# Altium schematic sheet PCIe PowerSupply.SchDoc
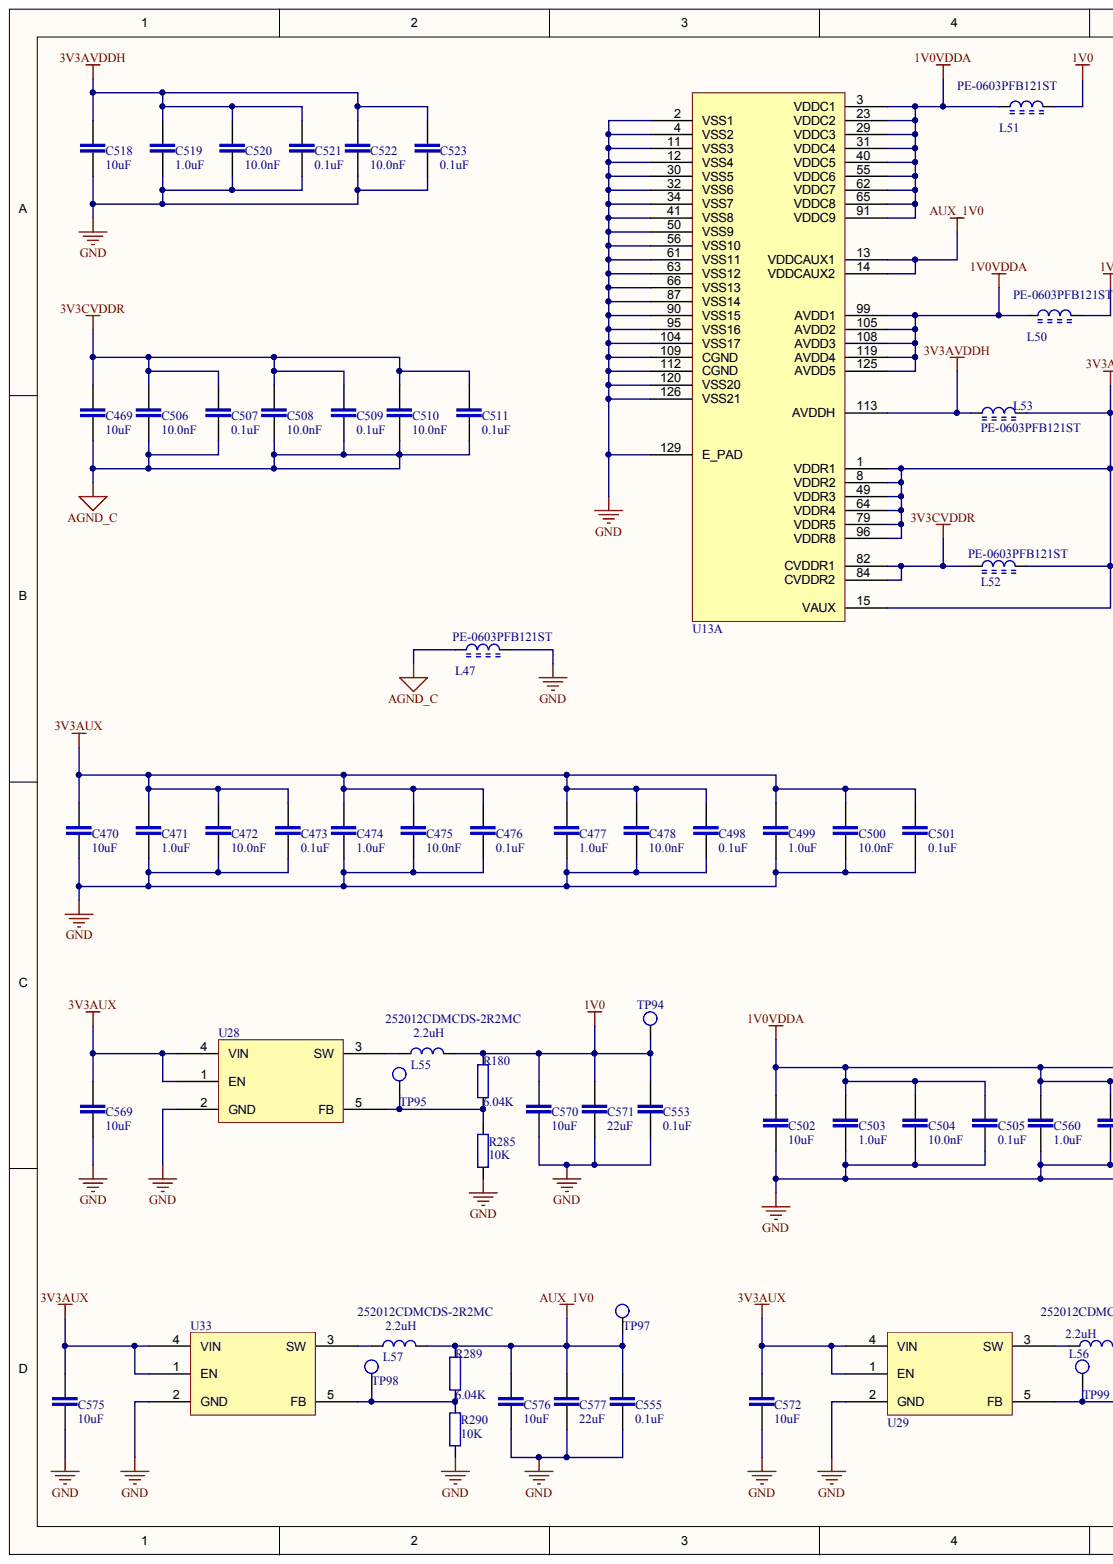
[diagram p0: PCIe PowerSupply.SchDoc, left]
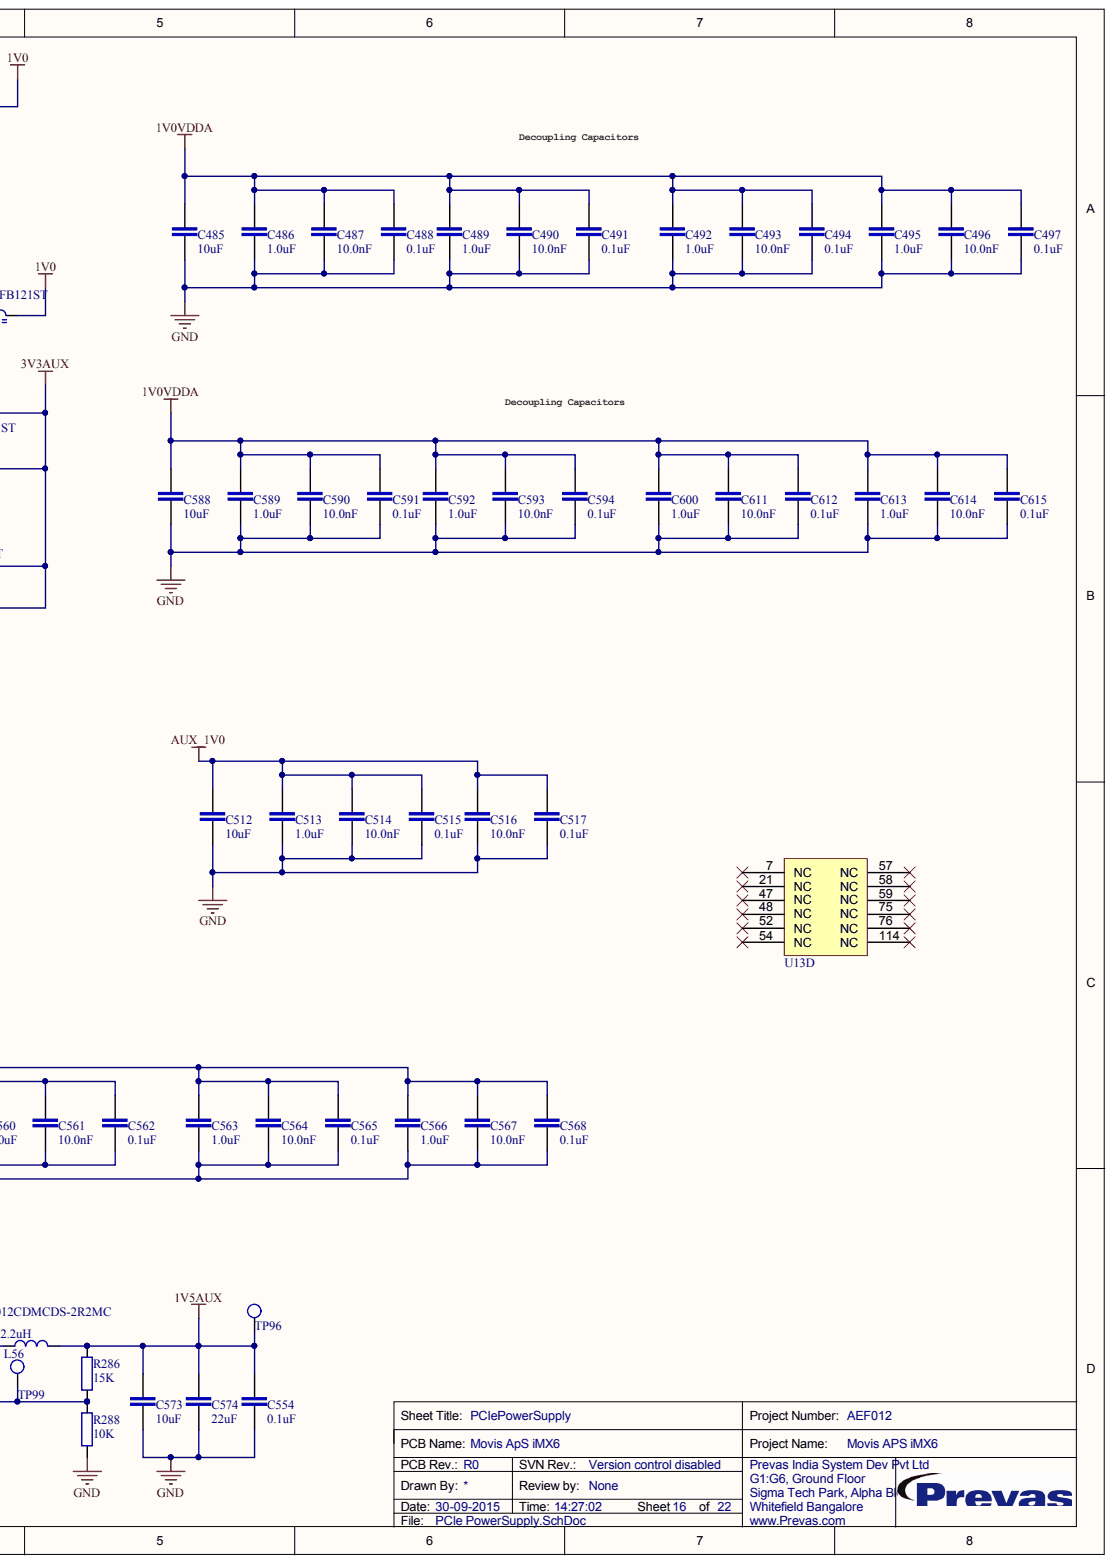
[diagram p1: PCIe PowerSupply.SchDoc, right]

## Parts
C469  10uF  [CAPC1608X95N]
C470  10uF  [CAPC1608X95N]
C471  1.0uF  [CAPC1608X90N]
C472  10.0nF  [CAPC1005X55N]
C473  0.1uF  [CAPC1005X55N]
C474  1.0uF  [CAPC1608X90N]
C475  10.0nF  [CAPC1005X55N]
C476  0.1uF  [CAPC1005X55N]
C477  1.0uF  [CAPC1608X90N]
C478  10.0nF  [CAPC1005X55N]
C485  10uF  [CAPC1608X95N]
C486  1.0uF  [CAPC1608X90N]
C487  10.0nF  [CAPC1005X55N]
C488  0.1uF  [CAPC1005X55N]
C489  1.0uF  [CAPC1608X90N]
C490  10.0nF  [CAPC1005X55N]
C491  0.1uF  [CAPC1005X55N]
C492  1.0uF  [CAPC1608X90N]
C493  10.0nF  [CAPC1005X55N]
C494  0.1uF  [CAPC1005X55N]
C495  1.0uF  [CAPC1608X90N]
C496  10.0nF  [CAPC1005X55N]
C497  0.1uF  [CAPC1005X55N]
C498  0.1uF  [CAPC1005X55N]
C499  1.0uF  [CAPC1608X90N]
C500  10.0nF  [CAPC1005X55N]
C501  0.1uF  [CAPC1005X55N]
C502  10uF  [CAPC1608X95N]
C503  1.0uF  [CAPC1608X90N]
C504  10.0nF  [CAPC1005X55N]
C505  0.1uF  [CAPC1005X55N]
C506  10.0nF  [CAPC1005X55N]
C507  0.1uF  [CAPC1005X55N]
C508  10.0nF  [CAPC1005X55N]
C509  0.1uF  [CAPC1005X55N]
C510  10.0nF  [CAPC1005X55N]
C511  0.1uF  [CAPC1005X55N]
C512  10uF  [CAPC1608X95N]
C513  1.0uF  [CAPC1608X90N]
C514  10.0nF  [CAPC1005X55N]
C515  0.1uF  [CAPC1005X55N]
C516  10.0nF  [CAPC1005X55N]
C517  0.1uF  [CAPC1005X55N]
C518  10uF  [CAPC1608X95N]
C519  1.0uF  [CAPC1608X90N]
C520  10.0nF  [CAPC1005X55N]
C521  0.1uF  [CAPC1005X55N]
C522  10.0nF  [CAPC1005X55N]
C523  0.1uF  [CAPC1005X55N]
C553  0.1uF  [CAPC1005X55N]
C554  0.1uF  [CAPC1005X55N]
C555  0.1uF  [CAPC1005X55N]
C560  1.0uF  [CAPC1608X90N]
C561  10.0nF  [CAPC1005X55N]
C562  0.1uF  [CAPC1005X55N]
C563  1.0uF  [CAPC1608X90N]
C564  10.0nF  [CAPC1005X55N]
C565  0.1uF  [CAPC1005X55N]
C566  1.0uF  [CAPC1608X90N]
C567  10.0nF  [CAPC1005X55N]
C568  0.1uF  [CAPC1005X55N]
C569  10uF  [CAPC1608X95N]
C570  10uF  [CAPC1608X95N]
C571  22uF  [CAPC3216X180N]
C572  10uF  [CAPC1608X95N]
C573  10uF  [CAPC1608X95N]
C574  22uF  [CAPC3216X180N]
C575  10uF  [CAPC1608X95N]
C576  10uF  [CAPC1608X95N]
C577  22uF  [CAPC3216X180N]
C588  10uF  [CAPC1608X95N]
C589  1.0uF  [CAPC1608X90N]
C590  10.0nF  [CAPC1005X55N]
C591  0.1uF  [CAPC1005X55N]
C592  1.0uF  [CAPC1608X90N]
C593  10.0nF  [CAPC1005X55N]
C594  0.1uF  [CAPC1005X55N]
C600  1.0uF  [CAPC1608X90N]
C611  10.0nF  [CAPC1005X55N]
C612  0.1uF  [CAPC1005X55N]
C613  1.0uF  [CAPC1608X90N]
C614  10.0nF  [CAPC1005X55N]
C615  0.1uF  [CAPC1005X55N]
L47  PE-0603PFB121ST  [INDC1608X100N]
L50  PE-0603PFB121ST  [INDC1608X100N]
L51  PE-0603PFB121ST  [INDC1608X100N]
L52  PE-0603PFB121ST  [INDC1608X100N]
L53  PE-0603PFB121ST  [INDC1608X100N]
L55  2.2uH  [INDC2621X12N]
L56  2.2uH  [INDC2621X12N]
L57  2.2uH  [INDC2621X12N]
R180  6.04K  [RESC1608X55N]
R285  10K  [RESC1608X55N]
R286  15K  [RESC1608X55N]
R288  10K  [RESC1608X55N]
R289  6.04K  [RESC1608X55N]
R290  10K  [RESC1608X55N]
TP94  Testpoint  [TP_SMD]  (value not drawn)  (no BOM)
TP95  Testpoint  [TP_SMD]  (value not drawn)  (no BOM)
TP96  Testpoint  [TP_SMD]  (value not drawn)  (no BOM)
TP97  Testpoint  [TP_SMD]  (value not drawn)  (no BOM)
TP98  Testpoint  [TP_SMD]  (value not drawn)  (no BOM)
TP99  Testpoint  [TP_SMD]  (value not drawn)  (no BOM)
U13  PI7C9X2G304_SCH  [LQFP40P1600X1600X160_HS-129N]  (value not drawn)  (parts A,D)
U28  AP3418KTR-G1  [SOT95P282X145-5N]  (value not drawn)
U29  AP3418KTR-G1  [SOT95P282X145-5N]  (value not drawn)
U33  AP3418KTR-G1  [SOT95P282X145-5N]  (value not drawn)

## Nets
1V0: C553.1 C570.2 C571.2 L50.2 L51.2 L55.2 R180.2 TP94.1
1V0VDDA: C485.2 C486.1 C487.2 C488.2 C489.1 C490.2 C491.2 C492.1 C493.2 C494.2 C495.1 C496.2 C497.2 C502.2 C503.1 C504.2 C505.2 C560.1 C561.2 C562.2 C563.1 C564.2 C565.2 C566.1 C567.2 C568.2 C588.2 C589.1 C590.2 C591.2 C592.1 C593.2 C594.2 C600.1 C611.2 C612.2 C613.1 C614.2 C615.2 L50.1 L51.1 U13.3(VDDC1) U13.23(VDDC2) U13.29(VDDC3) U13.31(VDDC4) U13.40(VDDC5) U13.55(VDDC6) U13.62(VDDC7) U13.65(VDDC8) U13.91(VDDC9) U13.99(AVDD1) U13.105(AVDD2) U13.108(AVDD3) U13.119(AVDD4) U13.125(AVDD5)
1V5AUX: C554.1 C573.2 C574.2 L56.2 R286.2 TP96.1
3V3AUX: C470.2 C471.1 C472.2 C473.2 C474.1 C475.2 C476.2 C477.1 C478.2 C498.2 C499.1 C500.2 C501.2 C569.2 C572.2 C575.2 L52.2 L53.1 U13.1(VDDR1) U13.8(VDDR2) U13.15(VAUX) U13.49(VDDR3) U13.64(VDDR4) U13.79(VDDR5) U13.96(VDDR8) U28.1(EN) U28.4(VIN) U29.1(EN) U29.4(VIN) U33.1(EN) U33.4(VIN)
3V3AVDDH: C518.2 C519.1 C520.2 C521.2 C522.2 C523.2 L53.2 U13.113(AVDDH)
3V3CVDDR: C469.2 C506.2 C507.2 C508.2 C509.2 C510.2 C511.2 L52.1 U13.82(CVDDR1) U13.84(CVDDR2)
AGND_C: C469.1 C506.1 C507.1 C508.1 C509.1 C510.1 C511.1 L47.1
AUX_1V0: C512.2 C513.1 C514.2 C515.2 C516.2 C517.2 C555.1 C576.2 C577.2 L57.2 R289.2 TP97.1 U13.13(VDDCAUX1) U13.14(VDDCAUX2)
GND: C470.1 C471.2 C472.1 C473.1 C474.2 C475.1 C476.1 C477.2 C478.1 C485.1 C486.2 C487.1 C488.1 C489.2 C490.1 C491.1 C492.2 C493.1 C494.1 C495.2 C496.1 C497.1 C498.1 C499.2 C500.1 C501.1 C502.1 C503.2 C504.1 C505.1 C512.1 C513.2 C514.1 C515.1 C516.1 C517.1 C518.1 C519.2 C520.1 C521.1 C522.1 C523.1 C553.2 C554.2 C555.2 C560.2 C561.1 C562.1 C563.2 C564.1 C565.1 C566.2 C567.1 C568.1 C569.1 C570.1 C571.1 C572.1 C573.1 C574.1 C575.1 C576.1 C577.1 C588.1 C589.2 C590.1 C591.1 C592.2 C593.1 C594.1 C600.2 C611.1 C612.1 C613.2 C614.1 C615.1 L47.2 R285.1 R288.1 R290.1 U13.2(VSS1) U13.4(VSS2) U13.11(VSS3) U13.12(VSS4) U13.30(VSS5) U13.32(VSS6) U13.34(VSS7) U13.41(VSS8) U13.50(VSS9) U13.56(VSS10) U13.61(VSS11) U13.63(VSS12) U13.66(VSS13) U13.87(VSS14) U13.90(VSS15) U13.95(VSS16) U13.104(VSS17) U13.109(CGND) U13.112(CGND) U13.120(VSS20) U13.126(VSS21) U13.129(E_PAD) U28.2(GND) U29.2(GND) U33.2(GND)
NetL55_1: L55.1 U28.3(SW)
NetL56_1: L56.1 U29.3(SW)
NetL57_1: L57.1 U33.3(SW)
NetR180_1: R180.1 R285.2 TP95.1 U28.5(FB)
NetR286_1: R286.1 R288.2 TP99.1 U29.5(FB)
NetR289_1: R289.1 R290.2 TP98.1 U33.5(FB)

Not connected: U13 7(NC) 21(NC) 47(NC) 48(NC) 52(NC) 54(NC) 57(NC) 58(NC) 59(NC) 75(NC) 76(NC) 114(NC)

## Library data of the parts
C469 C470 C485 C502 C512 C518 C569 C570 C572 C573 C575 C576 C588 = INNISI-78: CAP CER 10UF 16V 10% X5R 0603; ref_part_type: /capacitor/non_polarized/ceramic/; source_manufacturer_1: Murata; source_manufacturer_1_pn: GRM188R61C106KAALD; cae_altium_pcb_status: Prototype; Database Table Name: altium_default; db_rev: 7574; elec_temp_range: -55 to +85C; phy_height: 0.95mm; phy_mounting: SMD; phy_packaging_type: 0603; ref_datasheet: INNISI-75; ref_keyword: Ceramic capacitor X5R 0603; cae_altium_sch_status: Prototype; Component Kind: Standard; ComponentLink1Description: Datasheet; ComponentLink1URL: https://cop201.prevas.dk/viewvc/datasheet/INNISI-75.pdf?root=hw_components&view=co; db_created: 2015-08-03 05:08:42.0; db_last_updated: 03-08-2015 05:08:44; db_local_id: INNISI-78; db_status: Prototype; elec_tolerance: 10%; elec_value: 10uF; elec_voltage_rating: 16V; phy_material: X5R; Library Name: altium_mysql.dblib
C471 C474 C477 C486 C489 C492 C495 C499 C503 C513 C519 C560 C563 C566 C589 C592 C600 C613 = INNISI-79: CAP CER 1UF 16V 10% X7R 0603; ref_part_type: /capacitor/non_polarized/ceramic/; source_manufacturer_1: Murata; source_manufacturer_1_pn: GRM188R71C105KE15D; cae_altium_pcb_status: Prototype; Database Table Name: altium_default; db_rev: 7576; elec_temp_range: -55 to +125; phy_height: 0.90mm; phy_mounting: SMD; phy_packaging_type: 0603 (1608 Metric); ref_datasheet: INNISI-33; ref_keyword: Ceramic Capacitor 0603; cae_altium_sch_status: Prototype; Component Kind: Standard; ComponentLink1Description: Datasheet; ComponentLink1URL: https://cop201.prevas.dk/viewvc/datasheet/INNISI-33.pdf?root=hw_components&view=co; db_created: 2015-08-03 05:39:06.0; db_last_updated: 03-08-2015 05:39:08; db_local_id: INNISI-79; db_status: Prototype; elec_tolerance: 10%; elec_value: 1.0uF; elec_voltage_rating: 16V; phy_material: X7R; Library Name: altium_mysql.dblib
C472 C475 C478 C487 C490 C493 C496 C500 C504 C506 C508 C510 C514 C516 C520 C522 C561 C564 C567 C590 C593 C611 C614 = INNISI-82: CAP CER 10nF 50V 10% X7R 0402; ref_part_type: /capacitor/non_polarized/ceramic/; source_manufacturer_1: Yageo; source_manufacturer_1_pn: CC0402KRX7R9BB103; cae_altium_pcb_status: Prototype; Database Table Name: altium_default; db_rev: 7579; elec_temp_range: -55 to +125C; phy_height: 0.55mm; phy_mounting: SMD; phy_packaging_type: 0402; ref_datasheet: INNISI-72; ref_keyword: Ceramic capacitor; cae_altium_sch_status: Prototype; Component Kind: Standard; ComponentLink1Description: Datasheet; ComponentLink1URL: https://cop201.prevas.dk/viewvc/datasheet/INNISI-72.pdf?root=hw_components&view=co; db_created: 2015-08-03 05:52:48.0; db_last_updated: 03-08-2015 05:52:50; db_local_id: INNISI-82; db_status: Prototype; elec_tolerance: 10%; elec_value: 10.0nF; elec_voltage_rating: 50V; phy_material: X7R; Library Name: altium_mysql.dblib
C473 C476 C488 C491 C494 C497 C498 C501 C505 C507 C509 C511 C515 C517 C521 C523 C553-C555 C562 C565 C568 C591 C594 C612 C615 = INNISI-73: CAP CER 0.1UF 50V 10% X5R 0402; ref_part_type: /capacitor/non_polarized/ceramic/; source_manufacturer_1: TDK Corporation; source_manufacturer_1_pn: C1005X5R1H104K050BB; cae_altium_pcb_status: Prototype; Database Table Name: altium_default; db_rev: 7569; elec_temp_range: -55 to +85 C; phy_height: 0.55mm; phy_mounting: SMD; phy_packaging_type: 0402; ref_datasheet: INNISI-71; cae_altium_sch_status: Prototype; Component Kind: Standard; ComponentLink1Description: Datasheet; ComponentLink1URL: https://cop201.prevas.dk/viewvc/datasheet/INNISI-71.pdf?root=hw_components&view=co; db_created: 2015-08-03 03:34:59.0; db_last_updated: 03-08-2015 03:35:01; db_local_id: INNISI-73; db_status: Prototype; elec_tolerance: ±10%; elec_value: 0.1uF; elec_voltage_rating: 50V; phy_material: X5R; ref_keyword: CAP CER 0.1UF 50V 10% X5R 0402; Library Name: altium_mysql.dblib
C571 C574 C577 = INNISI-77: CAP CER 22UF 25V 10% X5R 1206; ref_part_type: /capacitor/non_polarized/ceramic/; source_manufacturer_1: Murata Electronics; source_manufacturer_1_pn: GRM31CR61E226KE15L; cae_altium_pcb_status: Prototype; Database Table Name: altium_default; db_rev: 7573; elec_temp_range: -55 to +85 C; phy_height: 1.8mm; phy_mounting: SMD; phy_packaging_type: 1206; ref_datasheet: INNISI-74; ref_keyword: Multilayer chip capacitor; cae_altium_sch_status: Prototype; Component Kind: Standard; ComponentLink1Description: Datasheet; ComponentLink1URL: https://cop201.prevas.dk/viewvc/datasheet/INNISI-74.pdf?root=hw_components&view=co; db_created: 2015-08-03 04:56:21.0; db_last_updated: 03-08-2015 04:56:22; db_local_id: INNISI-77; db_status: Prototype; elec_tolerance: 10%; elec_value: 22uF; elec_voltage_rating: 25V; phy_material: X5R; Library Name: altium_mysql.dblib
L47 L50-L53 = INNISI-23: EMI Filter Beads, Chips & Arrays 0603 120Ohms SMT FERRITE CHIP BEAD; ref_part_type: /inductor/; source_manufacturer_1: Pulse; cae_altium_pcb_status: Prototype; Database Table Name: altium_default; db_rev: 7356; elec_frequency_rating: 100MHz; elec_tolerance: ±25%; phy_mounting: SMD; phy_packaging_type: 0603; ref_keyword: EMI FERRITE CHIP BEAD; cae_altium_sch_status: Prototype; Component Kind: Standard; ComponentLink1Description: Datasheet; ComponentLink1URL: https://cop201.prevas.dk/viewvc/datasheet/INNISI-23.pdf?root=hw_components&view=co; db_created: 2015-06-02 08:57:45.0; db_last_updated: 02-06-2015 09:16:16; db_local_id: INNISI-23; db_status: Prototype; elec_value: PE-0603PFB121ST; ref_datasheet: INNISI-23; source_manufacturer_1_pn: PE-0603PFB121ST; Library Name: altium_mysql.dblib
L55-L57 = INNISI-24: Fixed Inductors SMD Power Inductor 2.2 uH 20% TOL; ref_part_type: /inductor/power/; source_manufacturer_1: Sumida; source_manufacturer_1_pn: 252012CDMCDS-2R2MC; cae_altium_pcb_status: Prototype; Database Table Name: altium_default; db_rev: 7357; elec_current_rating: 2.3A; elec_frequency_rating: 1MHz; elec_temp_range: - 40 C to + 105 C; phy_height: 2mm; phy_packaging_type: 1008 (2520 metric); ref_keyword: Power Inductors; cae_altium_sch_status: Prototype; Component Kind: Standard; ComponentLink1Description: Datasheet; ComponentLink1URL: https://cop201.prevas.dk/viewvc/datasheet/INNISI-24.pdf?root=hw_components&view=co; db_created: 2015-06-02 09:11:00.0; db_last_updated: 02-06-2015 09:17:06; db_local_id: INNISI-24; db_status: Prototype; elec_value: 2.2uH; phy_mounting: SMD; ref_datasheet: INNISI-24; Library Name: altium_mysql.dblib
R180 R289 = INVIHA-16: RES SMD 6.04K OHM 1% 1/10W 0603; ref_part_type: /resistor/fixed/; source_manufacturer_1: Yageo; source_manufacturer_1_pn: RC0603FR-076K04L; cae_altium_pcb_status: Prototype; Database Table Name: altium_default; db_rev: 7598; elec_power_rating: 0.1W; elec_temp_range: -55 to +155° C; phy_height: 0.55mm; phy_packaging_type: 0603; ref_datasheet: INVIHA-2; ref_keyword: Chip Resistor; cae_altium_sch_status: Prototype; Component Kind: Standard; ComponentLink1Description: Datasheet; ComponentLink1URL: https://cop201.prevas.dk/viewvc/datasheet/INVIHA-2.pdf?root=hw_components&view=co; db_created: 2015-08-03 07:02:54.0; db_last_updated: 03-08-2015 7:02:56 AM; db_local_id: INVIHA-16; db_status: Prototype; elec_tolerance: 1%; elec_value: 6.04K; phy_mounting: SMD; Library Name: altium_mysql.dblib
R285 R288 R290 = INVIHA-3: RES SMD 10K OHM 1% 1/10W 0603; ref_part_type: /resistor/fixed/; source_manufacturer_1: Yageo; source_manufacturer_1_pn: RC0603FR-0710KL; cae_altium_pcb_status: Prototype; Database Table Name: altium_default; db_rev: 7575; elec_power_rating: 0.1W; elec_temp_range: -55 to +155° C; phy_height: 0.55mm; phy_packaging_type: 0603; ref_datasheet: INVIHA-2; ref_keyword: Chip Resistor; cae_altium_sch_status: Prototype; Component Kind: Standard; ComponentLink1Description: Datasheet; ComponentLink1URL: https://cop201.prevas.dk/viewvc/datasheet/INVIHA-2.pdf?root=hw_components&view=co; db_created: 2015-08-03 05:39:03.0; db_last_updated: 03-08-2015 5:39:05 AM; db_local_id: INVIHA-3; db_status: Prototype; elec_tolerance: 1%; elec_value: 10K; phy_mounting: SMD; Library Name: altium_mysql.dblib
R286 = INVIHA-23: RES SMD 15K OHM 1% 1/10W 0603; ref_part_type: /resistor/fixed/; source_manufacturer_1: Yageo; source_manufacturer_1_pn: RC0603FR-0715KL; cae_altium_pcb_status: Prototype; Database Table Name: altium_default; db_rev: 7613; elec_power_rating: 0.1W; elec_temp_range: -55 to +155° C; phy_height: 0.55mm; phy_packaging_type: 0603; ref_keyword: Chip Resistor; cae_altium_sch_status: Prototype; Component Kind: Standard; ComponentLink1Description: Datasheet; ComponentLink1URL: https://cop201.prevas.dk/viewvc/datasheet/INVIHA-2.pdf?root=hw_components&view=co; db_created: 2015-08-03 08:11:15.0; db_last_updated: 03-08-2015 8:11:17 AM; db_local_id: INVIHA-23; db_status: Prototype; elec_tolerance: 1%; elec_value: 15K; phy_mounting: SMD; ref_datasheet: INVIHA-2; Library Name: altium_mysql.dblib
TP94-TP99 = JEPE-114: Test Point SMD; ref_part_type: /pcb_item/; cae_altium_pcb_status: Prototype; Database Table Name: altium_default; db_rev: 3281; cae_altium_sch_status: Prototype; ComponentLink1Description: Datasheet; db_created: 2008-08-18 11:39:42; db_last_updated: 23-03-2011 14:14:06; db_local_id: JEPE-114; db_status: Prototype; elec_value: Test Point; phy_mounting: SMD; ref_keyword: Test  Point; Library Name: altium_mysql.dblib
U13 = INNISI-104: PCI Interface IC 3 port 4 lane PCIe 2.0 Packet Switch; ref_part_type: /integrated/digital/interface/; source_manufacturer_1: Pericom; cae_altium_pcb_status: Prototype; Database Table Name: altium_default; db_rev: 7752; elec_frequency_rating: 100 MHz; elec_power_rating: 0.65 W; elec_temp_range: -40 C - +85 C; elec_value: PI7C9X2G304SLBFDEX; elec_voltage_rating: 3.3 V; phy_mounting: SMD; phy_packaging_type: LQFP-128; ref_datasheet: INNISI-89; ref_keyword: Switch - PCIe; cae_altium_sch_status: Prototype; Component Kind: Standard; ComponentLink1Description: Datasheet; ComponentLink1URL: https://cop201.prevas.dk/viewvc/datasheet/INNISI-89.pdf?root=hw_components&view=co; db_created: 2015-08-18 05:29:31.0; db_last_updated: 18-08-2015 06:25:36; db_local_id: INNISI-104; db_status: Prototype; source_manufacturer_1_pn: PI7C9X2G304SLBFDEX; Library Name: altium_mysql.dblib
U28 U29 U33 = INNISI-12: IC REG BUCK SYNC ADJ 1.5A SOT25; ref_part_type: /integrated/analog/power/supply/linear/; source_manufacturer_1: Diodes Incorporated; cae_altium_pcb_status: Prototype; Database Table Name: altium_default; db_rev: 7331; elec_temp_range: -40°C ~ 85°C; elec_voltage_rating: 2.5V to 5.5V; phy_mounting: SMD; ref_keyword: SYNCHRONOUS DC-DC BUCK CONVERTER; cae_altium_sch_status: Prototype; Component Kind: Standard; ComponentLink1Description: Datasheet; ComponentLink1URL: https://cop201.prevas.dk/viewvc/datasheet/INNISI-12.pdf?root=hw_components&view=co; db_created: 2015-05-28 05:21:49.0; db_last_updated: 28-05-2015 05:21:51; db_local_id: INNISI-12; db_status: Prototype; elec_current_rating: 1.5A; elec_value: AP3418KTR-G1; phy_packaging_type: SOT25; ref_datasheet: INNISI-12; source_manufacturer_1_pn: AP3418KTR-G1; Library Name: altium_mysql.dblib
